# Altium schematic sheet Teensy.SchDoc(Teensy)
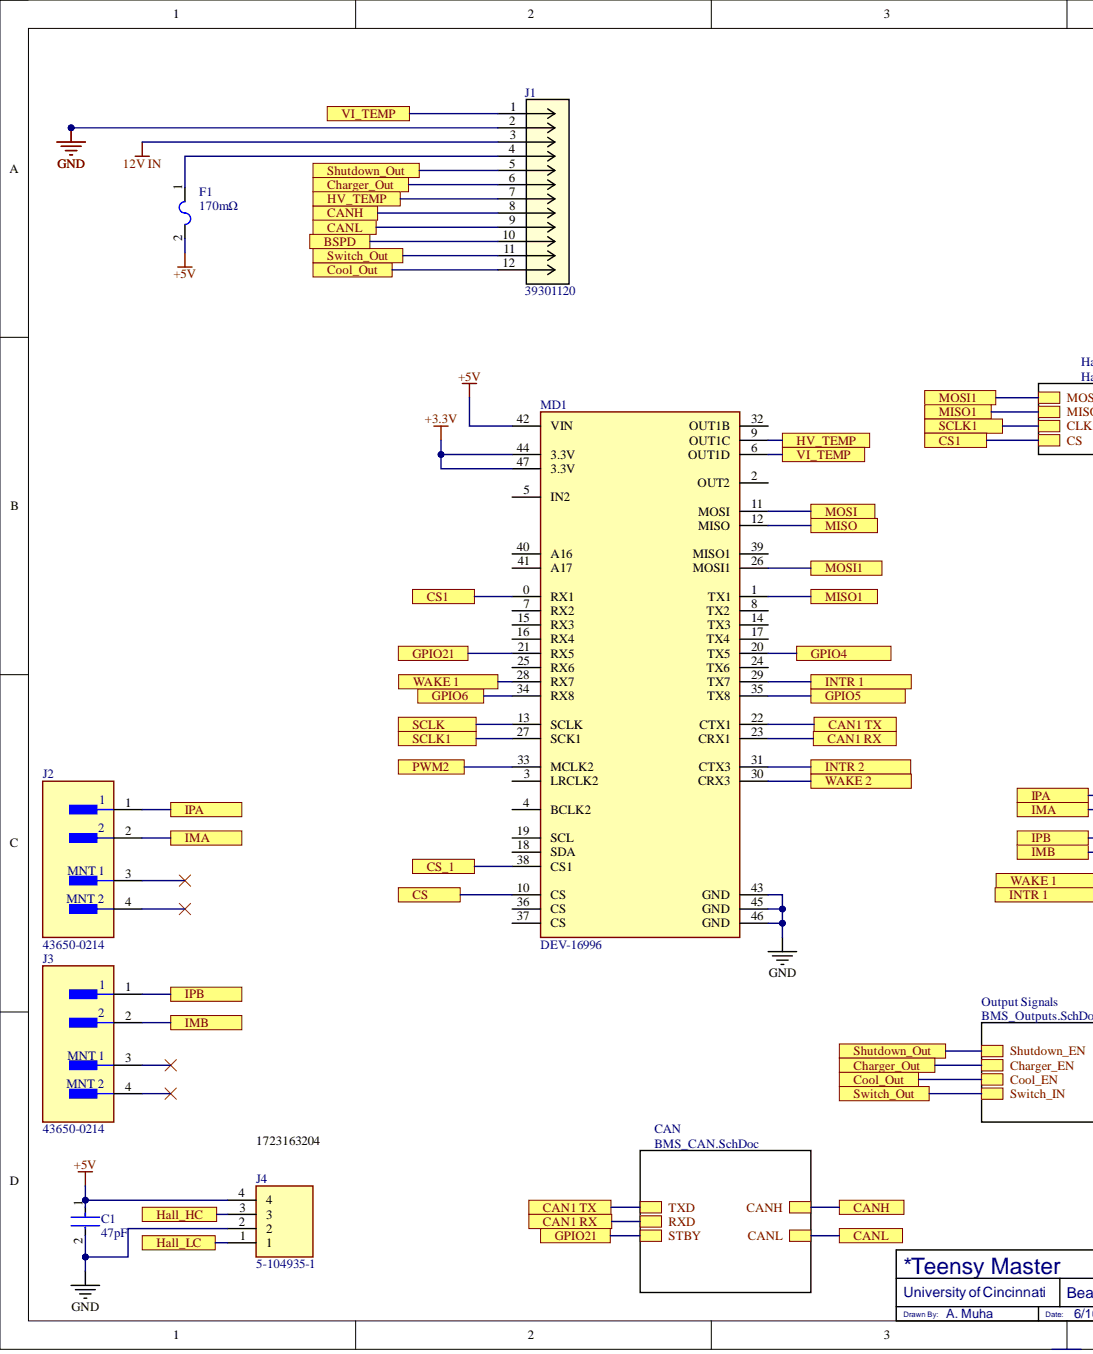
[diagram p0: Teensy.SchDoc, left]
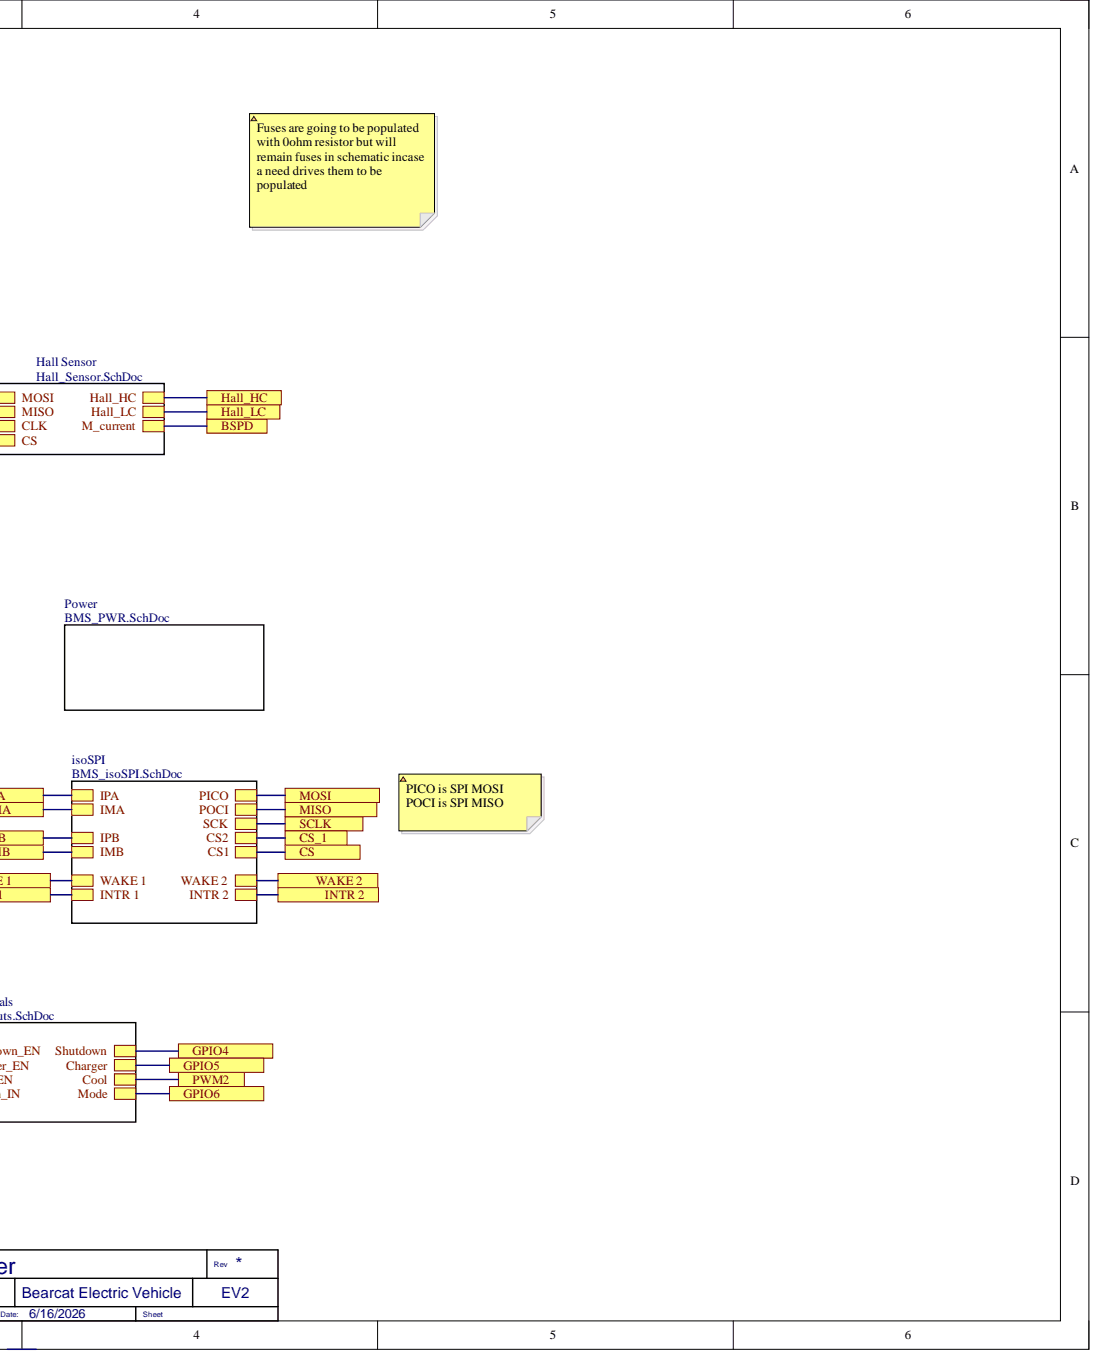
[diagram p1: Teensy.SchDoc, right]

## Parts
C1  value 47pF  (hidden comment: C0402C470J5GACTU)
F1  value 170mΩ  (hidden comment: MFU0603FF01000P500)
J1  39301120
J2  43650-0214
J3  43650-0214
J4  5-104935-1
MD1  DEV-16996

## Ports
BSPD, CAN1 RX, CAN1 TX, CANH, CANL, Charger_Out, Cool_Out, CS, CS1, CS_1, GPIO4, GPIO5, GPIO6, GPIO21, Hall_HC, Hall_LC, HV_TEMP, IMA, IMB, INTR 1, INTR 2, IPA, IPB, MISO, MISO1, MOSI, MOSI1, PWM2, SCLK, SCLK1, Shutdown_Out, Switch_Out, VI_TEMP, WAKE 1, WAKE 2

## Nets
12V IN: J1.3
+3.3V: MD1.44(3.3V) MD1.47(3.3V)
+5V: C1.1 F1.2 J4.4 MD1.42(VIN)
GND: C1.2 J1.2 J4.2 MD1.43(GND) MD1.45(GND) MD1.46(GND)
BSPD: J1.10 ; port BSPD
CAN1 RX: MD1.23(CRX1) ; port CAN1 RX
CAN1 TX: MD1.22(CTX1) ; port CAN1 TX
CANH: J1.8 ; port CANH
CANL: J1.9 ; port CANL
Charger_Out: J1.6 ; port Charger_Out
Cool_Out: J1.12 ; port Cool_Out
CS: MD1.10(CS) ; port CS
CS1: MD1.0(RX1) ; port CS1
CS_1: MD1.38(CS1) ; port CS_1
GPIO4: MD1.20(TX5) ; port GPIO4
GPIO5: MD1.35(TX8) ; port GPIO5
GPIO6: MD1.34(RX8) ; port GPIO6
GPIO21: MD1.21(RX5) ; port GPIO21
Hall_HC: J4.3 ; port Hall_HC
Hall_LC: J4.1 ; port Hall_LC
HV_TEMP: J1.7 MD1.9(OUT1C) ; port HV_TEMP
IMA: J2.2 ; port IMA
IMB: J3.2 ; port IMB
INTR 1: MD1.29(TX7) ; port INTR 1
INTR 2: MD1.31(CTX3) ; port INTR 2
IPA: J2.1 ; port IPA
IPB: J3.1 ; port IPB
MISO: MD1.12(MISO) ; port MISO
MISO1: MD1.1(TX1) ; port MISO1
MOSI: MD1.11(MOSI) ; port MOSI
MOSI1: MD1.26(MOSI1) ; port MOSI1
PWM2: MD1.33(MCLK2) ; port PWM2
SCLK: MD1.13(SCLK) ; port SCLK
SCLK1: MD1.27(SCK1) ; port SCLK1
Shutdown_Out: J1.5 ; port Shutdown_Out
Switch_Out: J1.11 ; port Switch_Out
VI_TEMP: J1.1 MD1.6(OUT1D) ; port VI_TEMP
WAKE 1: MD1.28(RX7) ; port WAKE 1
WAKE 2: MD1.30(CRX3) ; port WAKE 2
NetF1_1: F1.1 J1.4
NetJ2_3: J2.3
NetJ2_4: J2.4
NetJ3_3: J3.3
NetJ3_4: J3.4
NetMD1_2: MD1.2(OUT2)
NetMD1_3: MD1.3(LRCLK2)
NetMD1_4: MD1.4(BCLK2)
NetMD1_5: MD1.5(IN2)
NetMD1_7: MD1.7(RX2)
NetMD1_8: MD1.8(TX2)
NetMD1_14: MD1.14(TX3)
NetMD1_15: MD1.15(RX3)
NetMD1_16: MD1.16(RX4)
NetMD1_17: MD1.17(TX4)
NetMD1_18: MD1.18(SDA)
NetMD1_19: MD1.19(SCL)
NetMD1_24: MD1.24(TX6)
NetMD1_25: MD1.25(RX6)
NetMD1_32: MD1.32(OUT1B)
NetMD1_36: MD1.36(CS)
NetMD1_37: MD1.37(CS)
NetMD1_39: MD1.39(MISO1)
NetMD1_40: MD1.40(A16)
NetMD1_41: MD1.41(A17)

## Library data of the parts
C1 = CMP-059-000090-1: 50V 47pF C0G ±5% 0402 Multilayer Ceramic Capacitors MLCC - SMD/SMT ROHS; Temperature Coefficient: 30ppm/°C; Case Code (Imperial): 0402; Case/Package: 0402; China RoHS: Compliant; Component Kind: Standard; Contact Plating: Tin; Country of Origin: Mexico; Depth: 500µm; Dielectric: C0G; Dielectric Material: Ceramic; Export Control Classification Number (ECCN) Code: EAR99; Harmonized Tariff Schedule (HTS) Code: 8532.24.00.20; Height: 500µm; Introduction Date: 2008-01-10; Lead Free: Lead Free; Lead Pitch: 300µm; Length: 1mm; Lifecycle Status: Production; Material: Ceramic; Max Operating Temperature: 125°C; Min Operating Temperature: -55°C; Mounting Technology: Surface Mount; Number of Terminals: 2; Packaging: Cut Tape; Pins: 2; Radiation Hardening: No; REACH SVHC: Yes; RoHS: Compliant; Schedule B: 8532240020, 8532240020; Termination: SMD/SMT; Thickness: 558.8µm; Tolerance: 5%; Voltage: 50V; Voltage Rating: 50V; Voltage Rating (AC): 50V; Voltage Rating (DC): 50V; Width: 500µm; Library Name: BEV
F1 = CMP-263-000003-2: SMD Fuse, Very Fast Acting, 1 A, 32 VDC, 0603 [1608 Metric], MFU; Approvals: IEC, UL; Current Rating: 1A; Voltage Rating (DC): 32V; Case/Package: 0603; China RoHS: Non-Compliant; Component Kind: Standard; Depth: 850µm; Height: 450µm; Housing Material: Ceramic; Lead Free: Lead Free; Length: 1.55mm; Lifecycle Status: Production; Max Operating Temperature: 125°C; Min Operating Temperature: -55°C; Mounting Technology: Surface Mount; Packaging: Tape and Reel; Pins: 2; REACH SVHC: Yes; RoHS: Compliant; Width: 850µm; Library Name: BEV
J1 = CMP-133-000096-3: Mini-Fit Jr. Header, Dual Row, Right-Angle, with Snap-in Plastic Peg PCB  Lock, 12 Circuits, Nylon 6/6 94V-2, 2.54Âµm Matte Tin (Sn) Plating, Natural, Bag; Manufacturer_Name: Molex Connector Corporation; Component Kind: Standard; Design Item ID: 39301120; Manufacturer_Part_Number: 39301120; RefDes: RefDes; Source: 39301120.SchLib; Type: Type; Copyright: Copyright (C) 2025 Ultra Librarian. All rights reserved.; Library Name: BEV
J2 J3 = CMP-133-000077-1: Pin Header, Power, Wire-to-Board, 3 mm, 1 Rows, 2 Contacts, Surface Mount Right Angle; Component Kind: Standard; Library Name: BEV
J4 = CMP-133-000056-1: Connector; Manufacturer_Name: TE Connectivity; Arrow Part Number: 5-104935-1; Arrow Price/Stock: https://www.arrow.com/en/products/5-104935-1/te-connectivity; Component Kind: Standard; Datasheet Link: https://www.te.com/commerce/DocumentDelivery/DDEController?Action=srchrtrv&DocNm=104935&DocType=Customer+Drawing&DocLang=English; Design Item ID: 5-104935-1; Height: 6.91mm; Manufacturer_Part_Number: 5-104935-1; Mouser Part Number: 571-5-104935-1; Mouser Price/Stock: https://www.mouser.co.uk/ProductDetail/TE-Connectivity/5-104935-1?qs=Lu3apKW7jUgy3ABACwEQLg%3D%3D; Source: SamacSys.SchLib; Library Name: BEV
MD1 = CMP-00008-4: Teensy 4.1 (headers); Component Kind: Standard; Library Name: BEV
